# Altium schematic sheet Sheet1.SchDoc
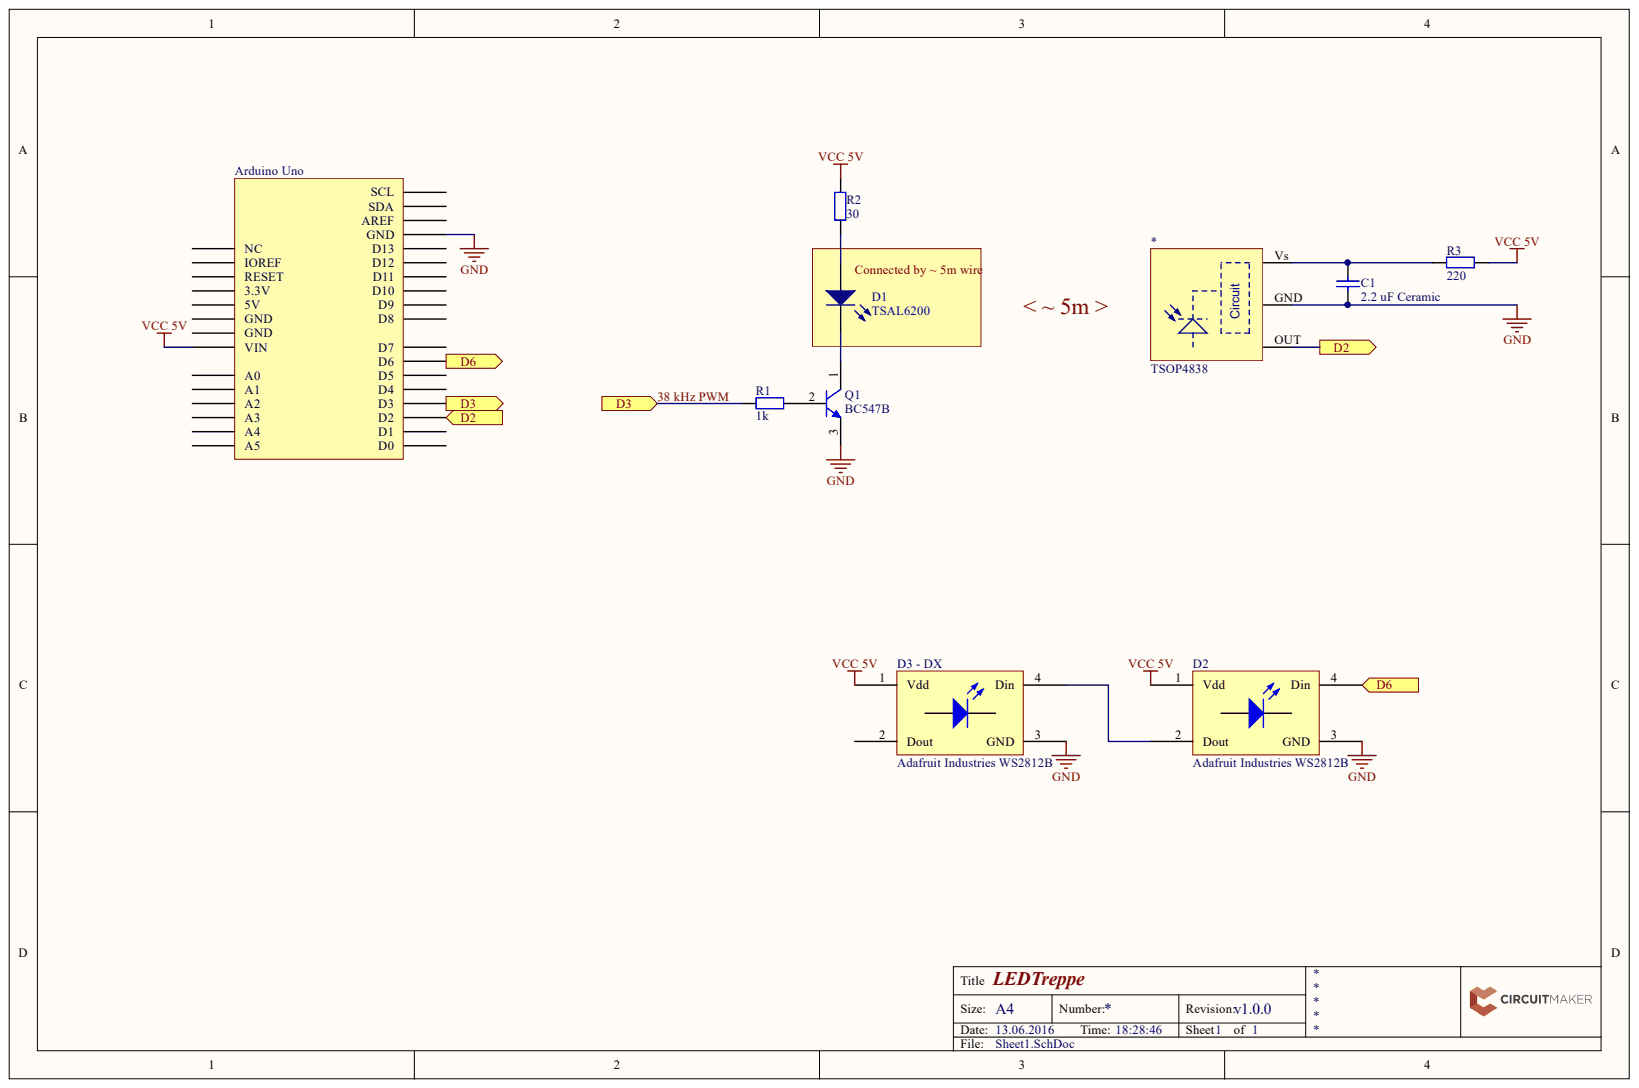
[diagram p0: Sheet1.SchDoc]

## Parts
*  TSOP4838  [PCB-y9t6zum2k1wxeoqc6omz-1]
Arduino_Uno  (no value)  [PCB-1073962-3]
C1  2.2 uF Ceramic  [CAPC1608X90X35LL10T15]
D1  TSAL6200  [PCB-kdn10wpwl4vrkqwfe8g0-1]
D2  Adafruit Industries WS2812B  [PCB-5u05b2cotxonj0zylsix-1]
D3_-_DX  Adafruit Industries WS2812B  [PCB-5u05b2cotxonj0zylsix-1]
Q1  BC547B  [ONSC-TO-92-3-29-11]
R1  1k  [PCB-1905203-1]
R2  30  [PCB-1905203-1]
R3  220  [PCB-1905203-1]

## Ports
D2, D3, D6

## Nets
GND: *.GND Arduino_Uno.GND3(GND) C1.1 D2.3(GND) D3_-_DX.3(GND) Q1.3
VCC 5V: Arduino_Uno.VIN D2.1 D3_-_DX.1 R2.2 R3.2
38 KHZ PWM: Arduino_Uno.D3 R1.1 ; port D3
D2: *.OUT Arduino_Uno.D2 ; port D2
D6: Arduino_Uno.D6 D2.4(Din) ; port D6
Net*_Vs: *.Vs C1.2 R3.1
NetD1_A: D1.A R2.1
NetD1_C: D1.C Q1.1
NetD2_2: D2.2(Dout) D3_-_DX.4(Din)
NetQ1_2: Q1.2 R1.2
< ~ 5M >:
CONNECTED BY ~ 5M WIRE:

Not connected: Arduino_Uno 3.3V 5V A0 A1 A2 A3 A4 A5 AREF D0 D1 D4 D5 D7 D8 D9 D10 D11 D12 D13 GND1(GND) GND2(GND) IOREF NC RESET SCL SDA; D3_-_DX 2(Dout)

## Library data of the parts
* = TSOP4838LL1: Logic Output Photo Detector; Component Kind: Standard; Library Name: Octopart library; Manufacturer Part Number: TSOP4838LL1; OctopartID: 11b5d8eb054ace72; Octopart URL: http://octopart.com/tsop4838ll1-vishay-5517721
Arduino_Uno = A000066: ARDUINO   A000066   ATMEGA328, ARDUINO UNO, EVAL BOARD; Core Architecture: AVR; Number of Bits: 8; Component Kind: Standard; Library Name: Octopart library; OctopartID: aa24626d5c2275e3; Octopart Url: https://octopart.com/a000066-arduino-21222240; REACH SVHC Compliance: No SVHC; RoHS: Compliant
C1 = CMP-119911-3: CAP CER 2.2UF 6.3V 10% X5R 0603; Capacitance: 2.2e-06 F; Case/Package (SI): 1608; Lead-Free Status: Lead Free; Capacitance Tolerance: ±10%; Component Kind: Standard; Dielectric Characteristic: X5R; Library Name: CommunityVault; Lifecycle Status: Active; OctopartID: 0c5fc512a2f7d398; Octopart Url: https://octopart.com/grm188r60j225ke19d-murata-4688846; RoHS: Compliant; Size-Length: 0.0016 m; Size-Thickness: 0.0008 m; Size-Width: 0.0008 m; Voltage Rating (DC): 6.3 V
D1 = CMP-e349290e9b643c8e-5: Ultra Violet UV 5mm 2000mcd LED; Forward Voltage: 3.6v; Lead-Free Status: Lead Free; Peak Wavelength: 410nm; Viewing Angle: 20 °; Wavelength: 400nm; Component Kind: Standard; Library Name: CommunityVault; Mounting Style: Through Hole; Number of Pins: 2; OctopartID: e349290e9b643c8e; Packaging: Bag of 100; RoHS: Compliant; URL: http://www.ebay.com/itm/291473921043
D2 D3_-_DX = WS2812B: LED RGB WS2812B W/DVR 4SMD 10PK; Vendor Part Number: 1528-1104-ND; Color: Red, Green, Blue (RGB); Vendor: Digikey; Component Kind: Standard; Library Name: Octopart library; Manufacturer Part Number: WS2812B; Mounting Type: Surface Mount; OctopartID: be34745cee7461f3; Octopart URL: http://octopart.com/ws2812b-adafruit+industries-56784166; Size / Dimension: 5.00mm L x 5.00mm W
Q1 = BC547B: Amplifier Transistor, NPN Silicon, 3-Pin TO-92, Bulk Box; Breakdown Voltage [Collector to Emitter]: 45.0 V; Current Rating: 0.1 A; Lead-Free Status: Contains Lead; Polarity: N-Channel; Power Dissipation: 0.625 W; Case/Package: TO-92; Component Kind: Standard; Library Name: Octopart library; Lifecycle Status: Not Listed by Manufacturer; Mounting Style: Through Hole; OctopartID: 8d24442bcf7c52e3; Octopart Url: https://octopart.com/bc547b-on+semiconductor-354110; Packaging: Bulk; RoHS: Compliant; Voltage Rating (DC): 45.0 V
R1 R3 = CR1206-FX-10R0ELF: Thick Film Resistors - SMD 10ohm 1%; Power Rating: 0.25 W; Resistance: 10.0 Ω; Voltage Rating (DC): 200.0 V; Case/Package: 1206; Component Kind: Standard; Library Name: Octopart library; Mounting Style: Surface Mount; Number of Pins: 2; OctopartID: 86e24fff7df95925; Octopart Url: https://octopart.com/cr1206-fx-10r0elf-bourns-1564285; REACH SVHC Compliance: No SVHC; Resistance Tolerance: ±1%; RoHS: Compliant; Size-Length: 0.0032004 m; Size-Thickness: 0.0006096 m; Size-Width: 0.0016002 m
R2 = CMP-1905203-2: Thick Film Resistors - SMD 10ohm 1%; Power Rating: 0.25 W; Resistance: 10.0 Ω; Voltage Rating (DC): 200.0 V; Case/Package: 1206; Component Kind: Standard; Library Name: CommunityVault; Mounting Style: Surface Mount; Number of Pins: 2; OctopartID: 86e24fff7df95925; Octopart Url: https://octopart.com/cr1206-fx-10r0elf-bourns-1564285; REACH SVHC Compliance: No SVHC; Resistance Tolerance: ±1%; RoHS: Compliant; Size-Length: 0.0032004 m; Size-Thickness: 0.0006096 m; Size-Width: 0.0016002 m
